# Altium schematic sheet Microcontroller.SchDoc
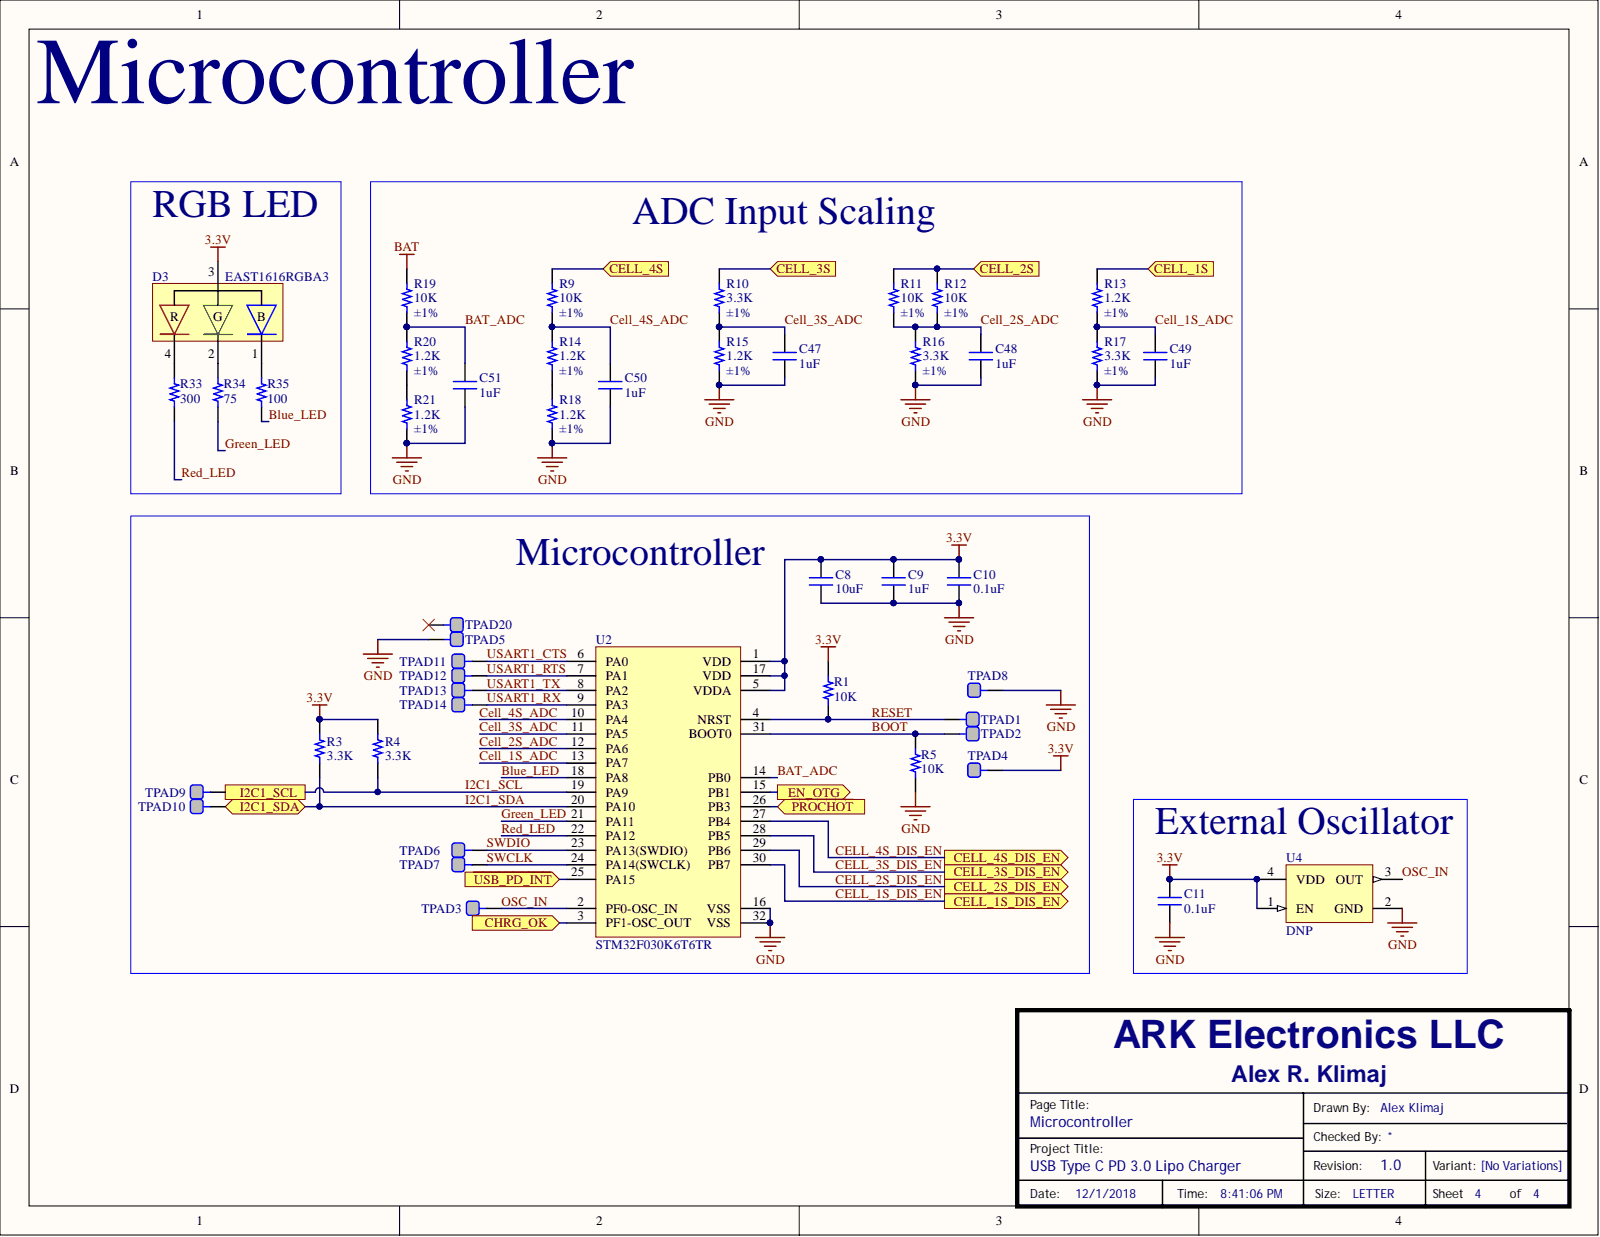
[diagram p0: Microcontroller.SchDoc]

## Parts
C8  10uF  [CAPC0805(2012)155_N]
C9  1uF  [CAPC0805(2012)155_N]
C10  0.1uF  [CAPC0805(2012)155_N]
C11  0.1uF  [CAPC0805(2012)155_N]
C47  1uF  [CAPC0805(2012)155_N]
C48  1uF  [CAPC0805(2012)155_N]
C49  1uF  [CAPC0805(2012)155_N]
C50  1uF  [CAPC0805(2012)155_N]
C51  1uF  [CAPC0805(2012)155_N]
D3  EAST1616RGBA3  [EAST1616RGBA3]
R1  10K  [RESC0805(2012)_L]
R3  3.3K  [RESC0805(2012)_L]
R4  3.3K  [RESC0805(2012)_L]
R5  10K  [RESC0805(2012)_L]
R9  10K  Tolerance: ±1%  [RESC0805(2012)_L]
R10  3.3K  Tolerance: ±1%  [RESC0805(2012)_L]
R11  10K  Tolerance: ±1%  [RESC0805(2012)_L]
R12  10K  Tolerance: ±1%  [RESC0805(2012)_L]
R13  1.2K  Tolerance: ±1%  [RESC0805(2012)_L]
R14  1.2K  Tolerance: ±1%  [RESC0805(2012)_L]
R15  1.2K  Tolerance: ±1%  [RESC0805(2012)_L]
R16  3.3K  Tolerance: ±1%  [RESC0805(2012)_L]
R17  3.3K  Tolerance: ±1%  [RESC0805(2012)_L]
R18  1.2K  Tolerance: ±1%  [RESC0805(2012)_L]
R19  10K  Tolerance: ±1%  [RESC0805(2012)_L]
R20  1.2K  Tolerance: ±1%  [RESC0805(2012)_L]
R21  1.2K  Tolerance: ±1%  [RESC0805(2012)_L]
R33  300  [RESC0805(2012)_L]
R34  75  [RESC0805(2012)_L]
R35  100  [RESC0805(2012)_L]
TPAD1  TEST_PAD  [TEST_POINT]  (value not drawn)  (no BOM)
TPAD2  TEST_PAD  [TEST_POINT]  (value not drawn)  (no BOM)
TPAD3  TEST_PAD  [TEST_PAD]  (value not drawn)  (no BOM)
TPAD4  TEST_PAD  [TEST_POINT]  (value not drawn)  (no BOM)
TPAD5  TEST_PAD  [TEST_POINT]  (value not drawn)  (no BOM)
TPAD6  TEST_PAD  [TEST_POINT]  (value not drawn)  (no BOM)
TPAD7  TEST_PAD  [TEST_POINT]  (value not drawn)  (no BOM)
TPAD8  TEST_PAD  [TEST_POINT]  (value not drawn)  (no BOM)
TPAD9  TEST_PAD  [TEST_POINT]  (value not drawn)  (no BOM)
TPAD10  TEST_PAD  [TEST_POINT]  (value not drawn)  (no BOM)
TPAD11  TEST_PAD  [TEST_POINT]  (value not drawn)  (no BOM)
TPAD12  TEST_PAD  [TEST_POINT]  (value not drawn)  (no BOM)
TPAD13  TEST_PAD  [TEST_POINT]  (value not drawn)  (no BOM)
TPAD14  TEST_PAD  [TEST_POINT]  (value not drawn)  (no BOM)
TPAD20  TEST_PAD  [TEST_POINT]  (value not drawn)  (no BOM)
U2  STM32F030K6T6TR  [LQFP32]
U4  DNP  [SiT8008BIA13-33E-8.000000D]

## Ports
CELL_1S, CELL_1S_DIS_EN, CELL_2S, CELL_2S_DIS_EN, CELL_3S, CELL_3S_DIS_EN, CELL_4S, CELL_4S_DIS_EN, CHRG_OK, EN_OTG, I2C1_SCL, I2C1_SDA, ~{PROCHOT}, ~{USB_PD_INT}

## Nets
3.3V: C8.2 C9.2 C10.2 C11.2 D3.3 R1.2 R3.2 R4.2 TPAD4.1 U2.1(VDD) U2.5(VDDA) U2.17(VDD) U4.1(EN) U4.4(VDD)
BAT: R19.2
GND: C8.1 C9.1 C10.1 C11.1 C47.1 C48.1 C49.1 C50.1 C51.1 R5.1 R15.1 R16.1 R17.1 R18.1 R21.1 TPAD5.1 TPAD8.1 U2.16(VSS) U2.32(VSS) U4.2(GND)
BAT_ADC: C51.2 R19.1 R20.2 U2.14(PB0)
Blue_LED: R35.1 U2.18(PA8)
BOOT: R5.2 TPAD2.1 U2.31(BOOT0)
CELL_1S: R13.2 ; port CELL_1S
Cell_1S_ADC: C49.2 R13.1 R17.2 U2.13(PA7)
CELL_1S_DIS_EN: U2.30(PB7) ; port CELL_1S_DIS_EN
CELL_2S: R11.2 R12.2 ; port CELL_2S
Cell_2S_ADC: C48.2 R11.1 R12.1 R16.2 U2.12(PA6)
CELL_2S_DIS_EN: U2.29(PB6) ; port CELL_2S_DIS_EN
CELL_3S: R10.2 ; port CELL_3S
Cell_3S_ADC: C47.2 R10.1 R15.2 U2.11(PA5)
CELL_3S_DIS_EN: U2.28(PB5) ; port CELL_3S_DIS_EN
CELL_4S: R9.2 ; port CELL_4S
Cell_4S_ADC: C50.2 R9.1 R14.2 U2.10(PA4)
CELL_4S_DIS_EN: U2.27(PB4) ; port CELL_4S_DIS_EN
CHRG_OK: U2.3(PF1-OSC_OUT) ; port CHRG_OK
EN_OTG: U2.15(PB1) ; port EN_OTG
Green_LED: R34.1 U2.21(PA11)
I2C1_SCL: R4.1 TPAD9.1 U2.19(PA9) ; port I2C1_SCL
I2C1_SDA: R3.1 TPAD10.1 U2.20(PA10) ; port I2C1_SDA
OSC_IN: TPAD3.1 U2.2(PF0-OSC_IN) U4.3(OUT)
~{PROCHOT}: U2.26(PB3) ; port ~{PROCHOT}
Red_LED: R33.1 U2.22(PA12)
RESET: R1.1 TPAD1.1 U2.4(NRST)
SWCLK: TPAD7.1 U2.24(PA14(SWCLK))
SWDIO: TPAD6.1 U2.23(PA13(SWDIO))
~{USB_PD_INT}: U2.25(PA15) ; port ~{USB_PD_INT}
USART1_CTS: TPAD11.1 U2.6(PA0)
USART1_RTS: TPAD12.1 U2.7(PA1)
USART1_RX: TPAD14.1 U2.9(PA3)
USART1_TX: TPAD13.1 U2.8(PA2)
NetD3_1: D3.1(B) R35.2
NetD3_2: D3.2(G) R34.2
NetD3_4: D3.4(R) R33.2
NetR14_1: R14.1 R18.2
NetR20_1: R20.1 R21.2

Not connected: TPAD20 1

## Library data of the parts
C8 = 30220: SMT Capacitor; Manufacturer: TDK Corporation; Mfr Part Description: CAP CER 10uF ±20% 35V X5R 0805 (2012 Metric); Mfr Part Number: C2012X5R1V106M085AC; Database Table Name: Parts; Dielectric: X5R; Tolerance: ±20%; Updated By: aklimaj; Voltage_Rating: 35V; Component Kind: Standard; ComponentLink1Description: Datasheet; ComponentLink1URL: https://product.tdk.com/info/en/catalog/datasheets/mlcc_commercial_general_en.pdf; ComponentLink2Description: Supplier Part Details; ComponentLink2URL: https://www.digikey.com/product-detail/en/tdk-corporation/C2012X5R1V106M085AC/445-14419-1-ND/3956085; Created_Date: 2018/10/14 19:21:49; ID: 30220; Library Name: Parts.DbLib; LifeCycle: New; Package_Case: 0805 (2012 Metric); Part_Type: Capacitor; RoHS: Yes; Supplier: Digi-Key; Supplier Part Number: 445-14419-1-ND; Temperature Range: -55C to +85C; Updated_Date: 2018/10/19 21:37:43; Value: 10uF; Created By: aklimaj
C9 C47-C51 = 30222: SMT Capacitor; Manufacturer: Samsung Electro-Mechanics; Mfr Part Description: CAP CER 1uF ±10% 50V X7R 0805 (2012 Metric); Mfr Part Number: CL21B105KBFNNNG; Database Table Name: Parts; Dielectric: X7R; Tolerance: ±10%; Updated By: aklimaj; Voltage_Rating: 50V; Component Kind: Standard; ComponentLink1Description: Datasheet; ComponentLink1URL: http://www.samsungsem.com/kr/support/product-search/mlcc/CL21B105KBFNNNG.jsp; ComponentLink2Description: Supplier Part Details; ComponentLink2URL: https://www.digikey.com/product-detail/en/samsung-electro-mechanics/CL21B105KBFNNNG/1276-6470-1-ND/5958098; Created_Date: 2018/10/14 19:30:56; ID: 30222; Library Name: Parts.DbLib; LifeCycle: New; Package_Case: 0805 (2012 Metric); Part_Type: Capacitor; RoHS: Yes; Supplier: Digi-Key; Supplier Part Number: 1276-6470-1-ND; Temperature Range: -55C to +125C; Updated_Date: 2018/10/19 21:37:42; Value: 1uF; Created By: aklimaj
C10 C11 = 30221: SMT Capacitor; Manufacturer: Samsung Electro-Mechanics; Mfr Part Description: CAP CER 0.1uF ±10% 100V X7R 0805 (2012 Metric); Mfr Part Number: CL21B104KCFNNNE; Database Table Name: Parts; Dielectric: X7R; Tolerance: ±10%; Updated By: aklimaj; Voltage_Rating: 100V; Component Kind: Standard; ComponentLink1Description: Datasheet; ComponentLink1URL: http://www.samsungsem.com/kr/support/product-search/mlcc/CL21B104KCFNNNE.jsp; ComponentLink2Description: Supplier Part Details; ComponentLink2URL: https://www.digikey.com/product-detail/en/samsung-electro-mechanics/CL21B104KCFNNNE/1276-6840-1-ND/5961699; Created_Date: 2018/10/14 19:28:20; ID: 30221; Library Name: Parts.DbLib; LifeCycle: New; Package_Case: 0805 (2012 Metric); Part_Type: Capacitor; RoHS: Yes; Supplier: Digi-Key; Supplier Part Number: 1276-6840-1-ND; Temperature Range: -55C to +125C; Updated_Date: 2018/10/19 21:37:43; Value: 0.1uF; Created By: aklimaj
D3 = 30242: Manufacturer: Everlight Electronics Co Ltd; Mfr Part Description: LED RGB CLEAR 4SMD; Part_Type: Discrete; Current_Rating: 5mA Red, 5mA Green, 5mA Blue; Database Table Name: Parts; Updated By: aklimaj; Voltage_Rating: 1.95V Red, 2.8V Green, 2.8V Blue; Component Kind: Standard; ComponentLink1Description: Datasheet; ComponentLink1URL: https://everlightamericas.com/index.php?controller=attachment&id_attachment=1010; ComponentLink2Description: Supplier Part Details; ComponentLink2URL: https://www.digikey.com/product-detail/en/everlight-electronics-co-ltd/EAST1616RGBA3/1080-1550-1-ND/8510378; Created_Date: 2018/11/03 21:02:13; ID: 30242; Library Name: Parts.DbLib; LifeCycle: New; Mfr Part Number: EAST1616RGBA3; Package_Case: 0606 (1616 Metric); RoHS: Yes; Supplier: Digi-Key; Supplier Part Number: 1080-1550-1-ND; Updated_Date: 2018/11/03 21:20:43; Value: EAST1616RGBA3; Created By: aklimaj
R1 R5 = 30227: SMT Resistor; Manufacturer: Bourns Inc.; Mfr Part Description: RES 10K Ohm ±1% 1/8W 0805 (2012 Metric); Mfr Part Number: CR0805-FX-1002ELF; Database Table Name: Parts; Power_Rating: 0.125W, 1/8W; Temperature Coeff: ±100ppm/degC; Tolerance: ±1%; Updated By: aklimaj; Component Kind: Standard; ComponentLink1Description: Datasheet; ComponentLink1URL: https://www.bourns.com/docs/Product-Datasheets/CHPREZTR.pdf; ComponentLink2Description: Supplier Part Details; ComponentLink2URL: https://www.digikey.com/product-detail/en/bourns-inc/CR0805-FX-1002ELF/CR0805-FX-1002ELFCT-ND/3592928; Created_Date: 2018/10/16 22:16:46; ID: 30227; Library Name: Parts.DbLib; LifeCycle: New; Package_Case: 0805 (2012 Metric); Part_Type: Resistor; RoHS: Yes; Supplier: Digi-Key; Supplier Part Number: CR0805-FX-1002ELFCT-ND; Temperature Range: -55C to +155C; Updated_Date: 2018/10/19 21:37:37; Value: 10K; Created By: aklimaj
R3 R4 = 30235: SMT Resistor; Manufacturer: Stackpole Electronics Inc.; Mfr Part Description: RES 3.3K Ohm ±1% 1/8W 0805 (2012 Metric); Mfr Part Number: RMCF0805FT3K30; Database Table Name: Parts; Power_Rating: 0.125W, 1/8W; Temperature Coeff: ±100ppm/degC; Tolerance: ±1%; Updated By: aklimaj; Component Kind: Standard; ComponentLink1Description: Datasheet; ComponentLink1URL: https://www.seielect.com/Catalog/SEI-RMCF_RMCP.pdf; ComponentLink2Description: Supplier Part Details; ComponentLink2URL: https://www.digikey.com/product-detail/en/stackpole-electronics-inc/RMCF0805FT3K30/RMCF0805FT3K30CT-ND/1942399; Created_Date: 2018/11/02 19:30:31; ID: 30235; Library Name: Parts.DbLib; LifeCycle: New; Package_Case: 0805 (2012 Metric); Part_Type: Resistor; RoHS: Yes; Supplier: Digi-Key; Supplier Part Number: RMCF0805FT3K30CT-ND; Temperature Range: -55C to +155C; Updated_Date: 2018/11/02 19:31:08; Value: 3.3K; Created By: aklimaj
R9 R11 R12 R19 = 30227: SMT Resistor; Manufacturer: Bourns Inc.; Mfr Part Description: RES 10K Ohm ±1% 1/8W 0805 (2012 Metric); Mfr Part Number: CR0805-FX-1002ELF; Database Table Name: Parts; Power_Rating: 0.125W, 1/8W; Temperature Coeff: ±100ppm/degC; Updated By: aklimaj; Component Kind: Standard; ComponentLink1Description: Datasheet; ComponentLink1URL: https://www.bourns.com/docs/Product-Datasheets/CHPREZTR.pdf; ComponentLink2Description: Supplier Part Details; ComponentLink2URL: https://www.digikey.com/product-detail/en/bourns-inc/CR0805-FX-1002ELF/CR0805-FX-1002ELFCT-ND/3592928; Created_Date: 2018/10/16 22:16:46; ID: 30227; Library Name: Parts.DbLib; LifeCycle: New; Package_Case: 0805 (2012 Metric); Part_Type: Resistor; RoHS: Yes; Supplier: Digi-Key; Supplier Part Number: CR0805-FX-1002ELFCT-ND; Temperature Range: -55C to +155C; Updated_Date: 2018/10/19 21:37:37; Value: 10K; Created By: aklimaj
R10 R16 R17 = 30235: SMT Resistor; Manufacturer: Stackpole Electronics Inc.; Mfr Part Description: RES 3.3K Ohm ±1% 1/8W 0805 (2012 Metric); Mfr Part Number: RMCF0805FT3K30; Database Table Name: Parts; Power_Rating: 0.125W, 1/8W; Temperature Coeff: ±100ppm/degC; Updated By: aklimaj; Component Kind: Standard; ComponentLink1Description: Datasheet; ComponentLink1URL: https://www.seielect.com/Catalog/SEI-RMCF_RMCP.pdf; ComponentLink2Description: Supplier Part Details; ComponentLink2URL: https://www.digikey.com/product-detail/en/stackpole-electronics-inc/RMCF0805FT3K30/RMCF0805FT3K30CT-ND/1942399; Created_Date: 2018/11/02 19:30:31; ID: 30235; Library Name: Parts.DbLib; LifeCycle: New; Package_Case: 0805 (2012 Metric); Part_Type: Resistor; RoHS: Yes; Supplier: Digi-Key; Supplier Part Number: RMCF0805FT3K30CT-ND; Temperature Range: -55C to +155C; Updated_Date: 2018/11/02 19:31:08; Value: 3.3K; Created By: aklimaj
R13-R15 R18 R20 R21 = 30236: SMT Resistor; Manufacturer: TE Connectivity Passive Product; Mfr Part Description: RES 1.2K Ohm ±1% 1/8W 0805 (2012 Metric); Mfr Part Number: CRGCQ0805F1K2; Database Table Name: Parts; Power_Rating: 0.125W, 1/8W; Temperature Coeff: ±100ppm/degC; Updated By: aklimaj; Component Kind: Standard; ComponentLink1Description: Datasheet; ComponentLink1URL: https://www.te.com/commerce/DocumentDelivery/DDEController?Action=srchrtrv&DocNm=1773204-3&DocType=DS&DocLang=English; ComponentLink2Description: Supplier Part Details; ComponentLink2URL: https://www.digikey.com/product-detail/en/te-connectivity-passive-product/CRGCQ0805F1K2/A129750CT-ND/8577582; Created_Date: 2018/11/02 19:33:38; ID: 30236; Library Name: Parts.DbLib; LifeCycle: New; Package_Case: 0805 (2012 Metric); Part_Type: Resistor; RoHS: Yes; Supplier: Digi-Key; Supplier Part Number: A129750CT-ND; Temperature Range: -55C to +155C; Updated_Date: 2018/11/02 19:33:50; Value: 1.2K; Created By: aklimaj
R33 = 30243: SMT Resistor; Manufacturer: Stackpole Electronics Inc.; Mfr Part Description: RES 300 Ohm ±5% 1/8W 0805 (2012 Metric); Mfr Part Number: RMCF0805JT300R; Database Table Name: Parts; Power_Rating: 0.125W, 1/8W; Temperature Coeff: ±200ppm/degC; Tolerance: ±5%; Updated By: aklimaj; Component Kind: Standard; ComponentLink1Description: Datasheet; ComponentLink1URL: https://www.seielect.com/Catalog/SEI-RMCF_RMCP.pdf; ComponentLink2Description: Supplier Part Details; ComponentLink2URL: https://www.digikey.com/product-detail/en/stackpole-electronics-inc/RMCF0805JT300R/RMCF0805JT300RCT-ND/5049826; Created_Date: 2018/11/03 21:24:11; ID: 30243; Library Name: Parts.DbLib; LifeCycle: New; Package_Case: 0805 (2012 Metric); Part_Type: Resistor; RoHS: Yes; Supplier: Digi-Key; Supplier Part Number: RMCF0805JT300RCT-ND; Temperature Range: -55C to +155C; Updated_Date: 2018/11/03 21:24:27; Value: 300; Created By: aklimaj
R34 = 30244: SMT Resistor; Manufacturer: Stackpole Electronics Inc.; Mfr Part Description: RES 75 Ohm ±5% 1/8W 0805 (2012 Metric); Mfr Part Number: RMCF0805JT75R0; Database Table Name: Parts; Power_Rating: 0.125W, 1/8W; Temperature Coeff: ±200ppm/degC; Tolerance: ±5%; Updated By: aklimaj; Component Kind: Standard; ComponentLink1Description: Datasheet; ComponentLink1URL: https://www.seielect.com/Catalog/SEI-RMCF_RMCP.pdf; ComponentLink2Description: Supplier Part Details; ComponentLink2URL: https://www.digikey.com/product-detail/en/stackpole-electronics-inc/RMCF0805JT75R0/RMCF0805JT75R0CT-ND/1942538; Created_Date: 2018/11/03 21:30:27; ID: 30244; Library Name: Parts.DbLib; LifeCycle: New; Package_Case: 0805 (2012 Metric); Part_Type: Resistor; RoHS: Yes; Supplier: Digi-Key; Supplier Part Number: RMCF0805JT75R0CT-ND; Temperature Range: -55C to +155C; Updated_Date: 2018/11/03 21:30:43; Value: 75; Created By: aklimaj
R35 = 30245: SMT Resistor; Manufacturer: Bourns Inc.; Mfr Part Description: RES 100 Ohm ±5% 1/8W 0805 (2012 Metric); Mfr Part Number: CR0805-JW-101ELF; Database Table Name: Parts; Power_Rating: 0.125W, 1/8W; Temperature Coeff: ±200ppm/degC; Tolerance: ±5%; Updated By: aklimaj; Component Kind: Standard; ComponentLink1Description: Datasheet; ComponentLink1URL: https://www.bourns.com/docs/Product-Datasheets/CHPREZTR.pdf; ComponentLink2Description: Supplier Part Details; ComponentLink2URL: https://www.digikey.com/product-detail/en/bourns-inc/CR0805-JW-101ELF/CR0805-JW-101ELFCT-ND/3741013; Created_Date: 2018/11/03 21:31:47; ID: 30245; Library Name: Parts.DbLib; LifeCycle: New; Package_Case: 0805 (2012 Metric); Part_Type: Resistor; RoHS: Yes; Supplier: Digi-Key; Supplier Part Number: CR0805-JW-101ELFCT-ND; Temperature Range: -55C to +155C; Updated_Date: 2018/11/03 21:32:00; Value: 100; Created By: aklimaj
TPAD1-TPAD14 TPAD20 = 30015: Mfr Part Description: Test Point; Database Table Name: Parts; Updated By: aklimaj; ComponentLink1Description: Datasheet; ComponentLink2Description: Supplier Part Details; Created_Date: 2017/07/13 09:26:35; Description: TEST_PAD; ID: 30015; Library Name: Parts.DbLib; LifeCycle: New; Part_Type: Test Point; RoHS: Yes; Updated_Date: 2017/07/13 09:29:11; Created By: aklimaj
U2 = 30232: Manufacturer: STMicroelectronics; Mfr Part Description: IC MCU 32BIT 32KB FLASH 32LQFP; Part_Type: IC; Database Table Name: Parts; Sub_Type: MCU; Updated By: aklimaj; Component Kind: Standard; ComponentLink1Description: Datasheet; ComponentLink1URL: http://www.st.com/content/ccc/resource/technical/document/datasheet/a4/5d/0b/0e/87/c4/4d/71/DM00088500.pdf/files/DM00088500.pdf/jcr:content/translations/en.DM00088500.pdf; ComponentLink2Description: Supplier Part Details; ComponentLink2URL: https://www.digikey.com/product-detail/en/stmicroelectronics/STM32F030K6T6TR/497-17334-1-ND/7650388; Created_Date: 2018/10/29 20:36:55; ID: 30232; Library Name: Parts.DbLib; LifeCycle: New; Mfr Part Number: STM32F030K6T6TR; Package_Case: 32-LQFP; RoHS: Yes; Supplier: Digi-Key; Supplier Part Number: 497-17334-1-ND; Temperature Range: -40C to +85C (TA); Updated_Date: 2018/10/29 21:03:12; Value: STM32F030K6T6TR; Created By: aklimaj
U4 = 30183: Manufacturer: Abracon; Mfr Part Description: OSC MEMS 24.000MHZ CMOS SMD; Mfr Part Number: ASDM1-24.000MHZ-LC-T; Part_Type: OSC; Teal Part Number: 20476; Current_Rating: 10mA; Database Table Name: Parts; Notes: SiT8008BI-13-33E-24.000000E; Updated By: aklimaj; Value: ASDM1-24.000MHZ-LC-T; Voltage_Rating: 3.3V; Component Kind: Standard; ComponentLink1Description: Datasheet; ComponentLink1URL: http://www.abracon.com/Oscillators/ASDM.pdf; ComponentLink2Description: Supplier Part Details; ComponentLink2URL: https://www.digikey.com/product-detail/en/abracon-llc/ASDM1-24.000MHZ-LC-T/535-9790-1-ND/1873324; Created_Date: 2018/04/04 15:16:38; ID: 30183; Library Name: Parts.DbLib; LifeCycle: New; Package_Case: 4-SMD, No Lead; RoHS: Yes; Supplier: Digi-Key; Supplier Part Number: 535-9790-1-ND; Temperature Range: -40C to +85C; Updated_Date: 2018/10/19 21:38:08; Created By: aklimaj
